# Altium schematic sheet S7CAS-PLEIADES-CARTE-FILLE_P02_CONNECTEUR_FMC.SchDoc
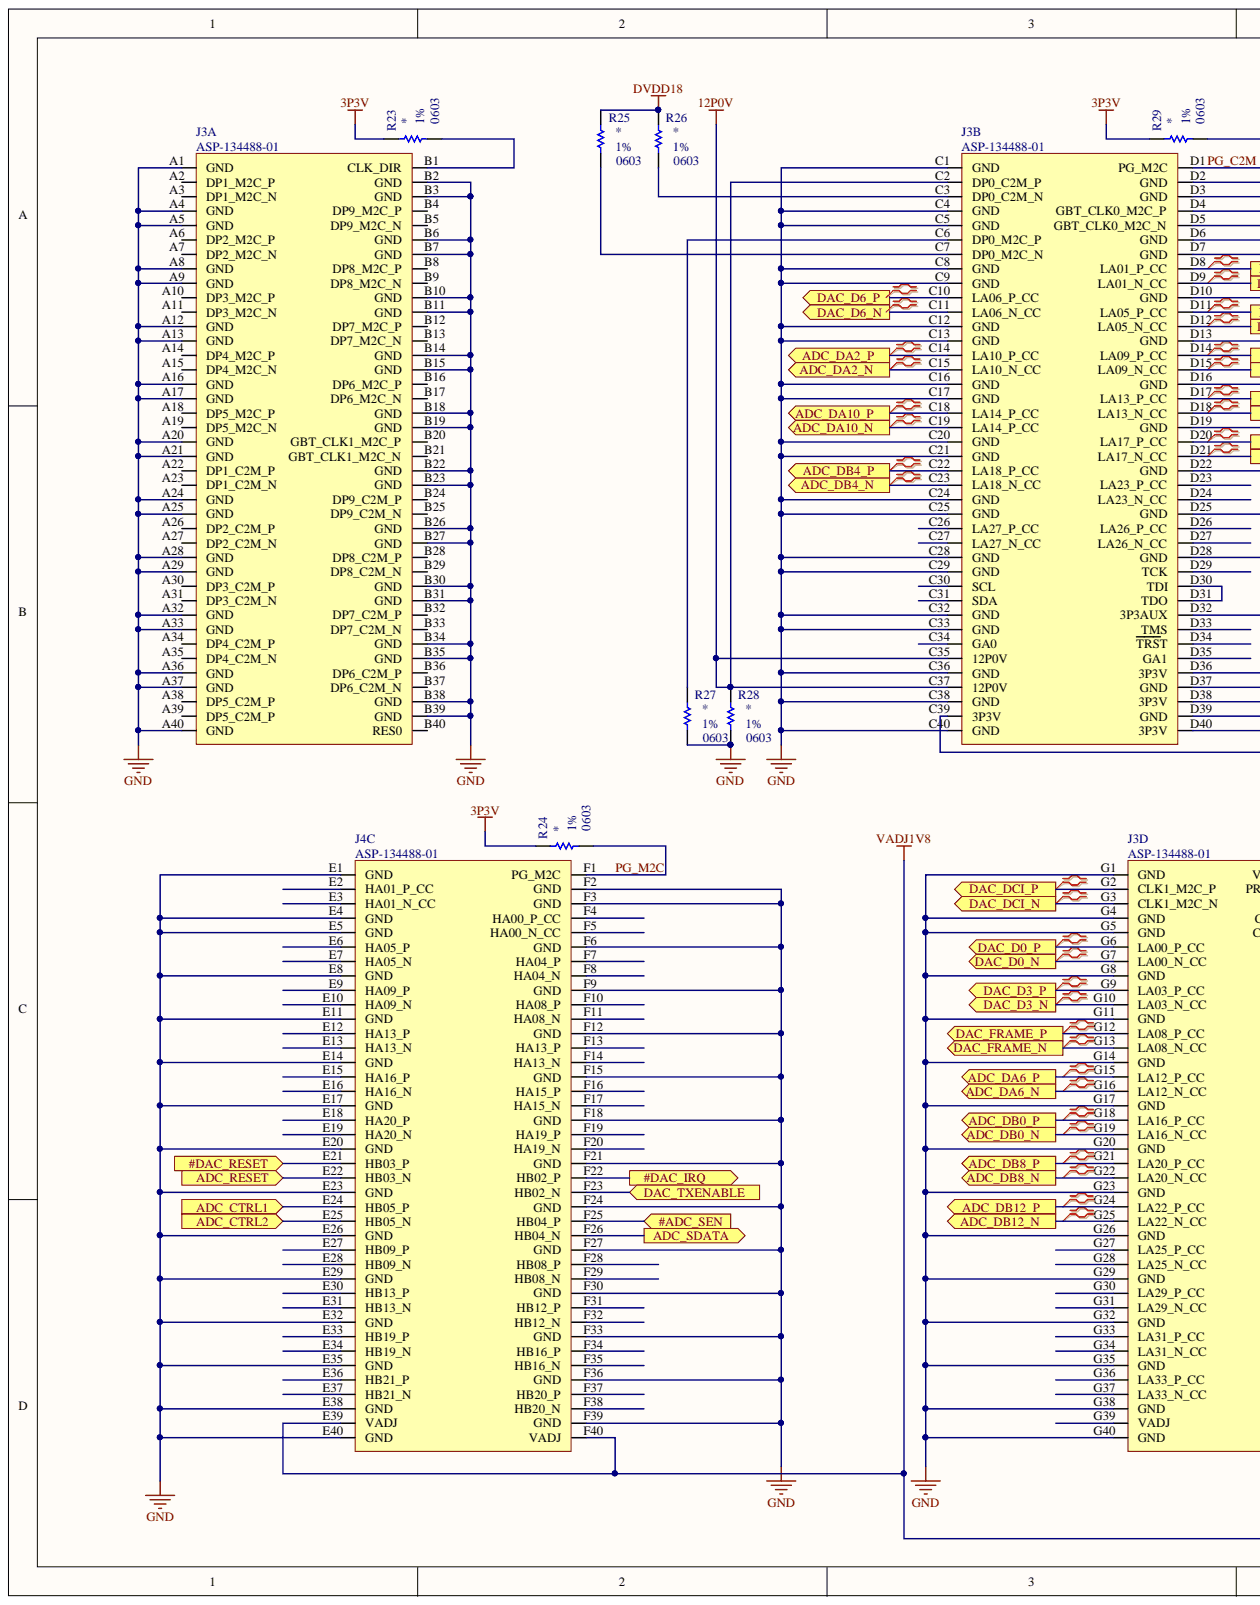
[diagram p0: S7CAS-PLEIADES-CARTE-FILLE_P02_CONNECTEUR_FMC.SchDoc, left]
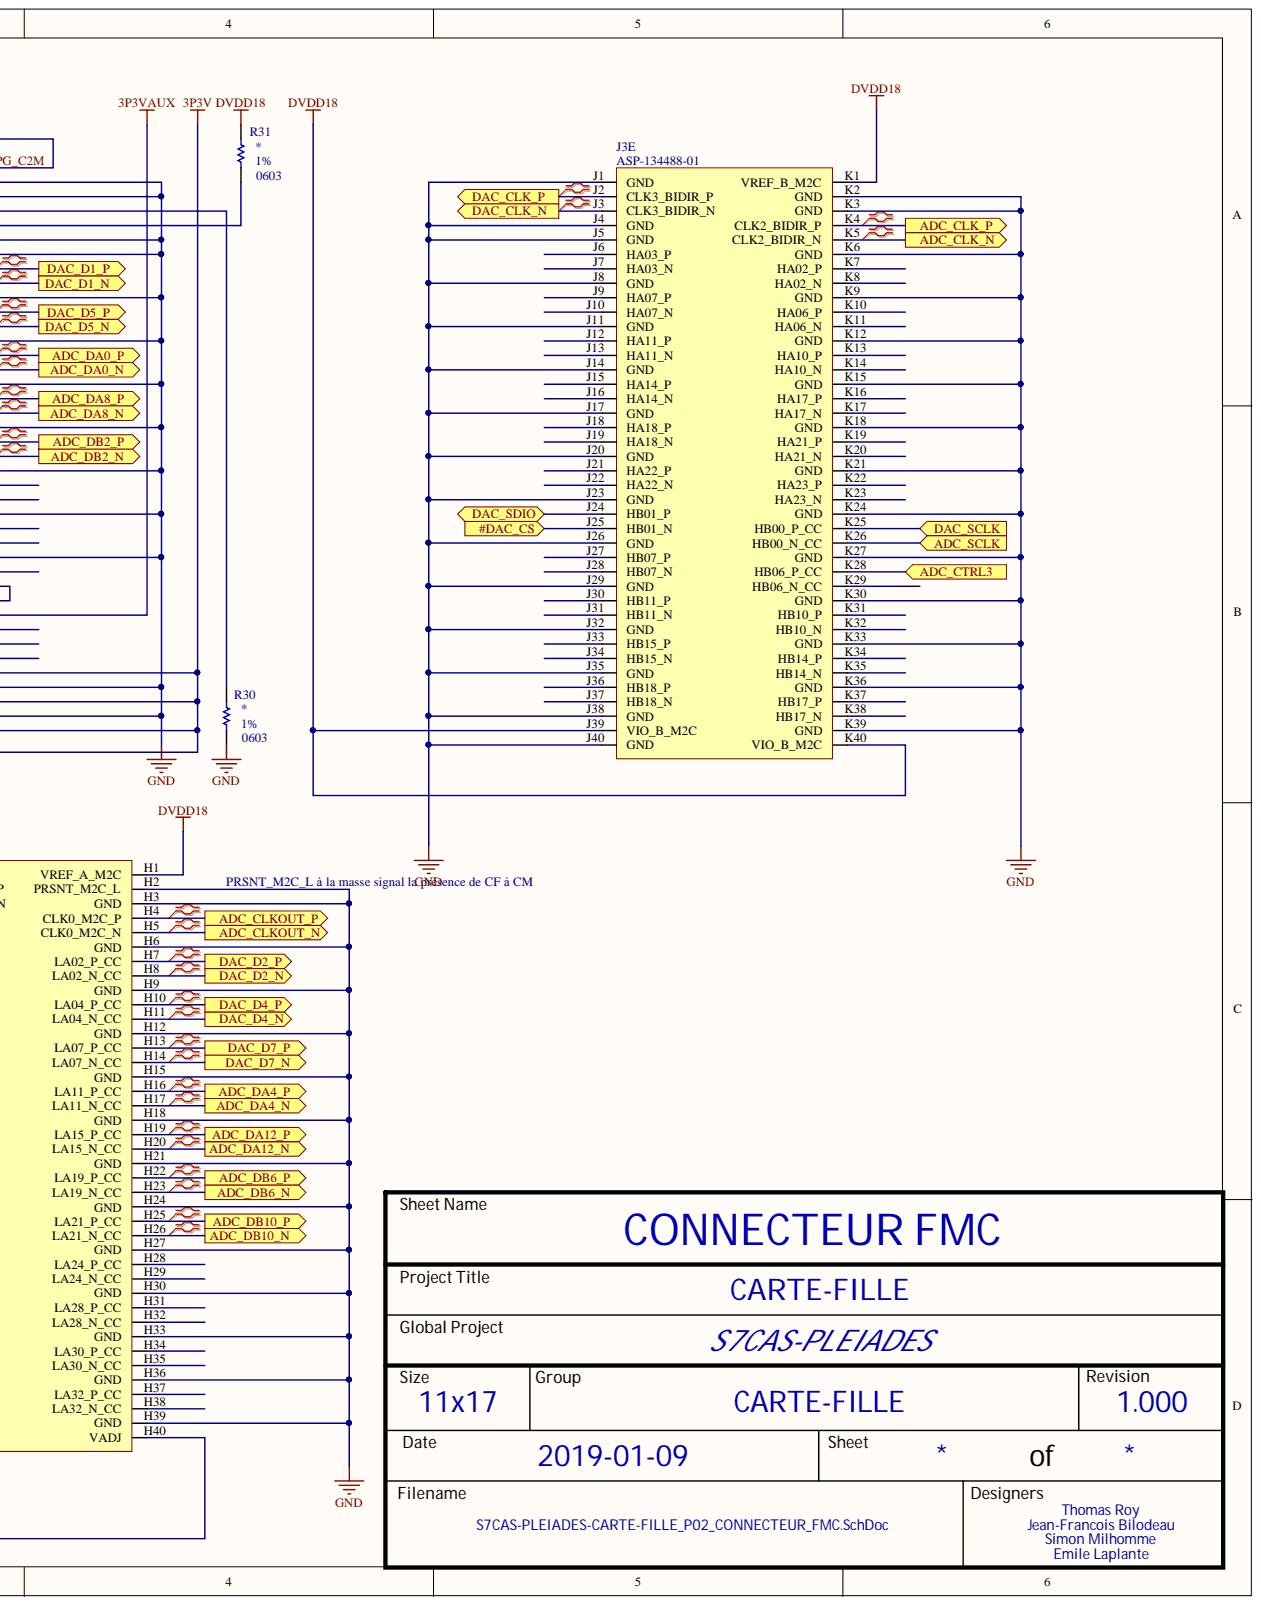
[diagram p1: S7CAS-PLEIADES-CARTE-FILLE_P02_CONNECTEUR_FMC.SchDoc, right]

## Parts
J3  ASP-134488-01  [CONN_SAMTEC_ASP-134488-01]  (parts A,B,D,E)
J4  ASP-134488-01  [CONN_SAMTEC_ASP-134488-01]  (part C)
R23  Package: 0603  Precision: 1%  [RES_0603]  (hidden comment: RES - 0603)
R24  Package: 0603  Precision: 1%  [RES_0603]  (hidden comment: RES - 0603)
R25  Package: 0603  Precision: 1%  [RES_0603]  (hidden comment: RES - 0603)
R26  Package: 0603  Precision: 1%  [RES_0603]  (hidden comment: RES - 0603)
R27  RES - 0603  [RES_0603]  (value not drawn)
R28  Package: 0603  Precision: 1%  [RES_0603]  (hidden comment: RES - 0603)
R29  Package: 0603  Precision: 1%  [RES_0603]  (hidden comment: RES - 0603)
R30  Package: 0603  Precision: 1%  [RES_0603]  (hidden comment: RES - 0603)
R31  Package: 0603  Precision: 1%  [RES_0603]  (hidden comment: RES - 0603)

## Ports
#ADC_SEN, #DAC_CS, #DAC_IRQ, #DAC_RESET, ADC_CLK_N, ADC_CLK_P, ADC_CLKOUT_N, ADC_CLKOUT_P, ADC_CTRL1, ADC_CTRL2, ADC_CTRL3, ADC_DA0_N, ADC_DA0_P, ADC_DA2_N, ADC_DA2_P, ADC_DA4_N, ADC_DA4_P, ADC_DA6_N, ADC_DA6_P, ADC_DA8_N, ADC_DA8_P, ADC_DA10_N, ADC_DA10_P, ADC_DA12_N, ADC_DA12_P, ADC_DB0_N, ADC_DB0_P, ADC_DB2_N, ADC_DB2_P, ADC_DB4_N, ADC_DB4_P, ADC_DB6_N, ADC_DB6_P, ADC_DB8_N, ADC_DB8_P, ADC_DB10_N, ADC_DB10_P, ADC_DB12_N, ADC_DB12_P, ADC_RESET, ADC_SCLK, ADC_SDATA, DAC_CLK_N, DAC_CLK_P, DAC_D0_N, DAC_D0_P, DAC_D1_N, DAC_D1_P, DAC_D2_N, DAC_D2_P, DAC_D3_N, DAC_D3_P, DAC_D4_N, DAC_D4_P, DAC_D5_N, DAC_D5_P, DAC_D6_N, DAC_D6_P, DAC_D7_N, DAC_D7_P, DAC_DCI_N, DAC_DCI_P, DAC_FRAME_N, DAC_FRAME_P, DAC_SCLK, DAC_SDIO, DAC_TXENABLE

## Nets
3P3V: J3.C39(3P3V) J3.D36(3P3V) J3.D38(3P3V) J3.D40(3P3V) R23.2 R24.2 R29.2
3P3VAUX: J3.D32(3P3AUX)
12P0V: J3.C2(DP0_C2M_P) J3.C35(12P0V) J3.C37(12P0V) R28.2
DVDD18: J3.H1(VREF_A_M2C) J3.J39(VIO_B_M2C) J3.K1(VREF_B_M2C) J3.K40(VIO_B_M2C) R25.2 R26.2 R31.2
GND: J3.A1(GND) J3.A4(GND) J3.A5(GND) J3.A8(GND) J3.A9(GND) J3.A12(GND) J3.A13(GND) J3.A16(GND) J3.A17(GND) J3.A20(GND) J3.A21(GND) J3.A24(GND) J3.A25(GND) J3.A28(GND) J3.A29(GND) J3.A32(GND) J3.A33(GND) J3.A36(GND) J3.A37(GND) J3.A40(GND) J3.B2(GND) J3.B3(GND) J3.B6(GND) J3.B7(GND) J3.B10(GND) J3.B11(GND) J3.B14(GND) J3.B15(GND) J3.B18(GND) J3.B19(GND) J3.B22(GND) J3.B23(GND) J3.B26(GND) J3.B27(GND) J3.B30(GND) J3.B31(GND) J3.B34(GND) J3.B35(GND) J3.B38(GND) J3.B39(GND) J3.C1(GND) J3.C4(GND) J3.C5(GND) J3.C8(GND) J3.C9(GND) J3.C12(GND) J3.C13(GND) J3.C16(GND) J3.C17(GND) J3.C20(GND) J3.C21(GND) J3.C24(GND) J3.C25(GND) J3.C28(GND) J3.C29(GND) J3.C32(GND) J3.C33(GND) J3.C36(GND) J3.C38(GND) J3.C40(GND) J3.D2(GND) J3.D3(GND) J3.D6(GND) J3.D7(GND) J3.D10(GND) J3.D13(GND) J3.D16(GND) J3.D19(GND) J3.D22(GND) J3.D25(GND) J3.D28(GND) J3.D37(GND) J3.D39(GND) J3.G1(GND) J3.G4(GND) J3.G5(GND) J3.G8(GND) J3.G11(GND) J3.G14(GND) J3.G17(GND) J3.G20(GND) J3.G23(GND) J3.G26(GND) J3.G29(GND) J3.G32(GND) J3.G35(GND) J3.G38(GND) J3.G40(GND) J3.H2(PRSNT_M2C_L) J3.H3(GND) J3.H6(GND) J3.H9(GND) J3.H12(GND) J3.H15(GND) J3.H18(GND) J3.H21(GND) J3.H24(GND) J3.H27(GND) J3.H30(GND) J3.H33(GND) J3.H36(GND) J3.H39(GND) J3.J1(GND) J3.J4(GND) J3.J5(GND) J3.J8(GND) J3.J11(GND) J3.J14(GND) J3.J17(GND) J3.J20(GND) J3.J23(GND) J3.J26(GND) J3.J29(GND) J3.J32(GND) J3.J35(GND) J3.J38(GND) J3.J40(GND) J3.K2(GND) J3.K3(GND) J3.K6(GND) J3.K9(GND) J3.K12(GND) J3.K15(GND) J3.K18(GND) J3.K21(GND) J3.K24(GND) J3.K27(GND) J3.K30(GND) J3.K33(GND) J3.K36(GND) J3.K39(GND) J4.E1(GND) J4.E4(GND) J4.E5(GND) J4.E8(GND) J4.E11(GND) J4.E14(GND) J4.E17(GND) J4.E20(GND) J4.E23(GND) J4.E26(GND) J4.E29(GND) J4.E32(GND) J4.E35(GND) J4.E38(GND) J4.E40(GND) J4.F2(GND) J4.F3(GND) J4.F6(GND) J4.F9(GND) J4.F12(GND) J4.F15(GND) J4.F18(GND) J4.F21(GND) J4.F24(GND) J4.F27(GND) J4.F30(GND) J4.F33(GND) J4.F36(GND) J4.F39(GND) R27.1 R28.1 R30.1
VADJ1V8: J3.H40(VADJ) J4.E39(VADJ) J4.F40(VADJ)
#ADC_SEN: J4.F25(HB04_P) ; port #ADC_SEN
#DAC_CS: J3.J25(HB01_N) ; port #DAC_CS
#DAC_IRQ: J4.F22(HB02_P) ; port #DAC_IRQ
#DAC_RESET: J4.E21(HB03_P) ; port #DAC_RESET
ADC_CLK_N: J3.K5(CLK2_BIDIR_N) ; port ADC_CLK_N
ADC_CLK_P: J3.K4(CLK2_BIDIR_P) ; port ADC_CLK_P
ADC_CLKOUT_N: J3.H5(CLK0_M2C_N) ; port ADC_CLKOUT_N
ADC_CLKOUT_P: J3.H4(CLK0_M2C_P) ; port ADC_CLKOUT_P
ADC_CTRL1: J4.E24(HB05_P) ; port ADC_CTRL1
ADC_CTRL2: J4.E25(HB05_N) ; port ADC_CTRL2
ADC_CTRL3: J3.K28(HB06_P_CC) ; port ADC_CTRL3
ADC_DA0_N: J3.D15(LA09_N_CC) ; port ADC_DA0_N
ADC_DA0_P: J3.D14(LA09_P_CC) ; port ADC_DA0_P
ADC_DA2_N: J3.C15(LA10_N_CC) ; port ADC_DA2_N
ADC_DA2_P: J3.C14(LA10_P_CC) ; port ADC_DA2_P
ADC_DA4_N: J3.H17(LA11_N_CC) ; port ADC_DA4_N
ADC_DA4_P: J3.H16(LA11_P_CC) ; port ADC_DA4_P
ADC_DA6_N: J3.G16(LA12_N_CC) ; port ADC_DA6_N
ADC_DA6_P: J3.G15(LA12_P_CC) ; port ADC_DA6_P
ADC_DA8_N: J3.D18(LA13_N_CC) ; port ADC_DA8_N
ADC_DA8_P: J3.D17(LA13_P_CC) ; port ADC_DA8_P
ADC_DA10_N: J3.C19(LA14_P_CC) ; port ADC_DA10_N
ADC_DA10_P: J3.C18(LA14_P_CC) ; port ADC_DA10_P
ADC_DA12_N: J3.H20(LA15_N_CC) ; port ADC_DA12_N
ADC_DA12_P: J3.H19(LA15_P_CC) ; port ADC_DA12_P
ADC_DB0_N: J3.G19(LA16_N_CC) ; port ADC_DB0_N
ADC_DB0_P: J3.G18(LA16_P_CC) ; port ADC_DB0_P
ADC_DB2_N: J3.D21(LA17_N_CC) ; port ADC_DB2_N
ADC_DB2_P: J3.D20(LA17_P_CC) ; port ADC_DB2_P
ADC_DB4_N: J3.C23(LA18_N_CC) ; port ADC_DB4_N
ADC_DB4_P: J3.C22(LA18_P_CC) ; port ADC_DB4_P
ADC_DB6_N: J3.H23(LA19_N_CC) ; port ADC_DB6_N
ADC_DB6_P: J3.H22(LA19_P_CC) ; port ADC_DB6_P
ADC_DB8_N: J3.G22(LA20_N_CC) ; port ADC_DB8_N
ADC_DB8_P: J3.G21(LA20_P_CC) ; port ADC_DB8_P
ADC_DB10_N: J3.H26(LA21_N_CC) ; port ADC_DB10_N
ADC_DB10_P: J3.H25(LA21_P_CC) ; port ADC_DB10_P
ADC_DB12_N: J3.G25(LA22_N_CC) ; port ADC_DB12_N
ADC_DB12_P: J3.G24(LA22_P_CC) ; port ADC_DB12_P
ADC_RESET: J4.E22(HB03_N) ; port ADC_RESET
ADC_SCLK: J3.K26(HB00_N_CC) ; port ADC_SCLK
ADC_SDATA: J4.F26(HB04_N) ; port ADC_SDATA
DAC_CLK_N: J3.J3(CLK3_BIDIR_N) ; port DAC_CLK_N
DAC_CLK_P: J3.J2(CLK3_BIDIR_P) ; port DAC_CLK_P
DAC_D0_N: J3.G7(LA00_N_CC) ; port DAC_D0_N
DAC_D0_P: J3.G6(LA00_P_CC) ; port DAC_D0_P
DAC_D1_N: J3.D9(LA01_N_CC) ; port DAC_D1_N
DAC_D1_P: J3.D8(LA01_P_CC) ; port DAC_D1_P
DAC_D2_N: J3.H8(LA02_N_CC) ; port DAC_D2_N
DAC_D2_P: J3.H7(LA02_P_CC) ; port DAC_D2_P
DAC_D3_N: J3.G10(LA03_N_CC) ; port DAC_D3_N
DAC_D3_P: J3.G9(LA03_P_CC) ; port DAC_D3_P
DAC_D4_N: J3.H11(LA04_N_CC) ; port DAC_D4_N
DAC_D4_P: J3.H10(LA04_P_CC) ; port DAC_D4_P
DAC_D5_N: J3.D12(LA05_N_CC) ; port DAC_D5_N
DAC_D5_P: J3.D11(LA05_P_CC) ; port DAC_D5_P
DAC_D6_N: J3.C11(LA06_N_CC) ; port DAC_D6_N
DAC_D6_P: J3.C10(LA06_P_CC) ; port DAC_D6_P
DAC_D7_N: J3.H14(LA07_N_CC) ; port DAC_D7_N
DAC_D7_P: J3.H13(LA07_P_CC) ; port DAC_D7_P
DAC_DCI_N: J3.G3(CLK1_M2C_N) ; port DAC_DCI_N
DAC_DCI_P: J3.G2(CLK1_M2C_P) ; port DAC_DCI_P
DAC_FRAME_N: J3.G13(LA08_N_CC) ; port DAC_FRAME_N
DAC_FRAME_P: J3.G12(LA08_P_CC) ; port DAC_FRAME_P
DAC_SCLK: J3.K25(HB00_P_CC) ; port DAC_SCLK
DAC_SDIO: J3.J24(HB01_P) ; port DAC_SDIO
DAC_TXENABLE: J4.F23(HB02_N) ; port DAC_TXENABLE
PG_C2M: J3.D1(PG_M2C) R29.1
PG_M2C: J4.F1(PG_M2C) R24.1
NetJ3_B1: J3.B1(CLK_DIR) R23.1
NetJ3_C3: J3.C3(DP0_C2M_N) R26.1
NetJ3_C6: J3.C6(DP0_M2C_P) R27.2
NetJ3_C7: J3.C7(DP0_M2C_N) R25.1
NetJ3_D4: J3.D4(GBT_CLK0_M2C_P) R30.2
NetJ3_D5: J3.D5(GBT_CLK0_M2C_N) R31.1
NetJ3_D30: J3.D30(TDI) J3.D31(TDO)

Not connected: J3 A2(DP1_M2C_P) A3(DP1_M2C_N) A6(DP2_M2C_P) A7(DP2_M2C_N) A10(DP3_M2C_P) A11(DP3_M2C_N) A14(DP4_M2C_P) A15(DP4_M2C_N) A18(DP5_M2C_P) A19(DP5_M2C_N) A22(DP1_C2M_P) A23(DP1_C2M_N) A26(DP2_C2M_P) A27(DP2_C2M_N) A30(DP3_C2M_P) A31(DP3_C2M_N) A34(DP4_C2M_P) A35(DP4_C2M_N) A38(DP5_C2M_P) A39(DP5_C2M_P) B4(DP9_M2C_P) B5(DP9_M2C_N) B8(DP8_M2C_P) B9(DP8_M2C_N) B12(DP7_M2C_P) B13(DP7_M2C_N) B16(DP6_M2C_P) B17(DP6_M2C_N) B20(GBT_CLK1_M2C_P) B21(GBT_CLK1_M2C_N) B24(DP9_C2M_P) B25(DP9_C2M_N) B28(DP8_C2M_P) B29(DP8_C2M_N) B32(DP7_C2M_P) B33(DP7_C2M_N) B36(DP6_C2M_P) B37(DP6_C2M_N) B40(RES0) C26(LA27_P_CC) C27(LA27_N_CC) C30(SCL) C31(SDA) C34(GA0) D23(LA23_P_CC) D24(LA23_N_CC) D26(LA26_P_CC) D27(LA26_N_CC) D29(TCK) D33(TMS) D34(TRST) D35(GA1) G27(LA25_P_CC) G28(LA25_N_CC) G30(LA29_P_CC) G31(LA29_N_CC) G33(LA31_P_CC) G34(LA31_N_CC) G36(LA33_P_CC) G37(LA33_N_CC) G39(VADJ) H28(LA24_P_CC) H29(LA24_N_CC) H31(LA28_P_CC) H32(LA28_N_CC) H34(LA30_P_CC) H35(LA30_N_CC) H37(LA32_P_CC) H38(LA32_N_CC) J6(HA03_P) J7(HA03_N) J9(HA07_P) J10(HA07_N) J12(HA11_P) J13(HA11_N) J15(HA14_P) J16(HA14_N) J18(HA18_P) J19(HA18_N) J21(HA22_P) J22(HA22_N) J27(HB07_P) J28(HB07_N) J30(HB11_P) J31(HB11_N) J33(HB15_P) J34(HB15_N) J36(HB18_P) J37(HB18_N) K7(HA02_P) K8(HA02_N) K10(HA06_P) K11(HA06_N) K13(HA10_P) K14(HA10_N) K16(HA17_P) K17(HA17_N) K19(HA21_P) K20(HA21_N) K22(HA23_P) K23(HA23_N) K29(HB06_N_CC) K31(HB10_P) K32(HB10_N) K34(HB14_P) K35(HB14_N) K37(HB17_P) K38(HB17_N); J4 E2(HA01_P_CC) E3(HA01_N_CC) E6(HA05_P) E7(HA05_N) E9(HA09_P) E10(HA09_N) E12(HA13_P) E13(HA13_N) E15(HA16_P) E16(HA16_N) E18(HA20_P) E19(HA20_N) E27(HB09_P) E28(HB09_N) E30(HB13_P) E31(HB13_N) E33(HB19_P) E34(HB19_N) E36(HB21_P) E37(HB21_N) F4(HA00_P_CC) F5(HA00_N_CC) F7(HA04_P) F8(HA04_N) F10(HA08_P) F11(HA08_N) F13(HA13_P) F14(HA13_N) F16(HA15_P) F17(HA15_N) F19(HA19_P) F20(HA19_N) F28(HB08_P) F29(HB08_N) F31(HB12_P) F32(HB12_N) F34(HB16_P) F35(HB16_N) F37(HB20_P) F38(HB20_N)

## Library data of the parts
J3 J4 = CONN_SAMTEC_ASP-134488-01: CONN - VITA 57 MEZZ MALE (DAUGHTERBOARD) HIGH DENSITY - SMD-400; Current: 1.8A; Mfg: Samtec; Voltage: 240VAC; Component Kind: Standard; HelpURL: http://suddendocs.samtec.com/prints/asp-134488-01-mkt.pdf; Library Name: S7CAS_Lib2019_REV1_00.IntLib; Mfg Part Number: ASP-134488-01; Package: SMD-400; Temperature: -55 °C to +125 °C; CreatedBy: Jonathan Bouchard
R27 = RES - 0603: Precision: 1%; Component Kind: Standard; Description: RES - 0603; Library Name: S7CAS_Lib2019_REV1_00.IntLib; Package: 0603; CreatedBy: Jonathan Bouchard
R23-R26 R28-R31 = RES - 0603: Component Kind: Standard; Description: RES - 0603; Library Name: S7CAS_Lib2019_REV1_00.IntLib; CreatedBy: Jonathan Bouchard
